# Altium schematic sheet 02-TxCoil.SchDoc
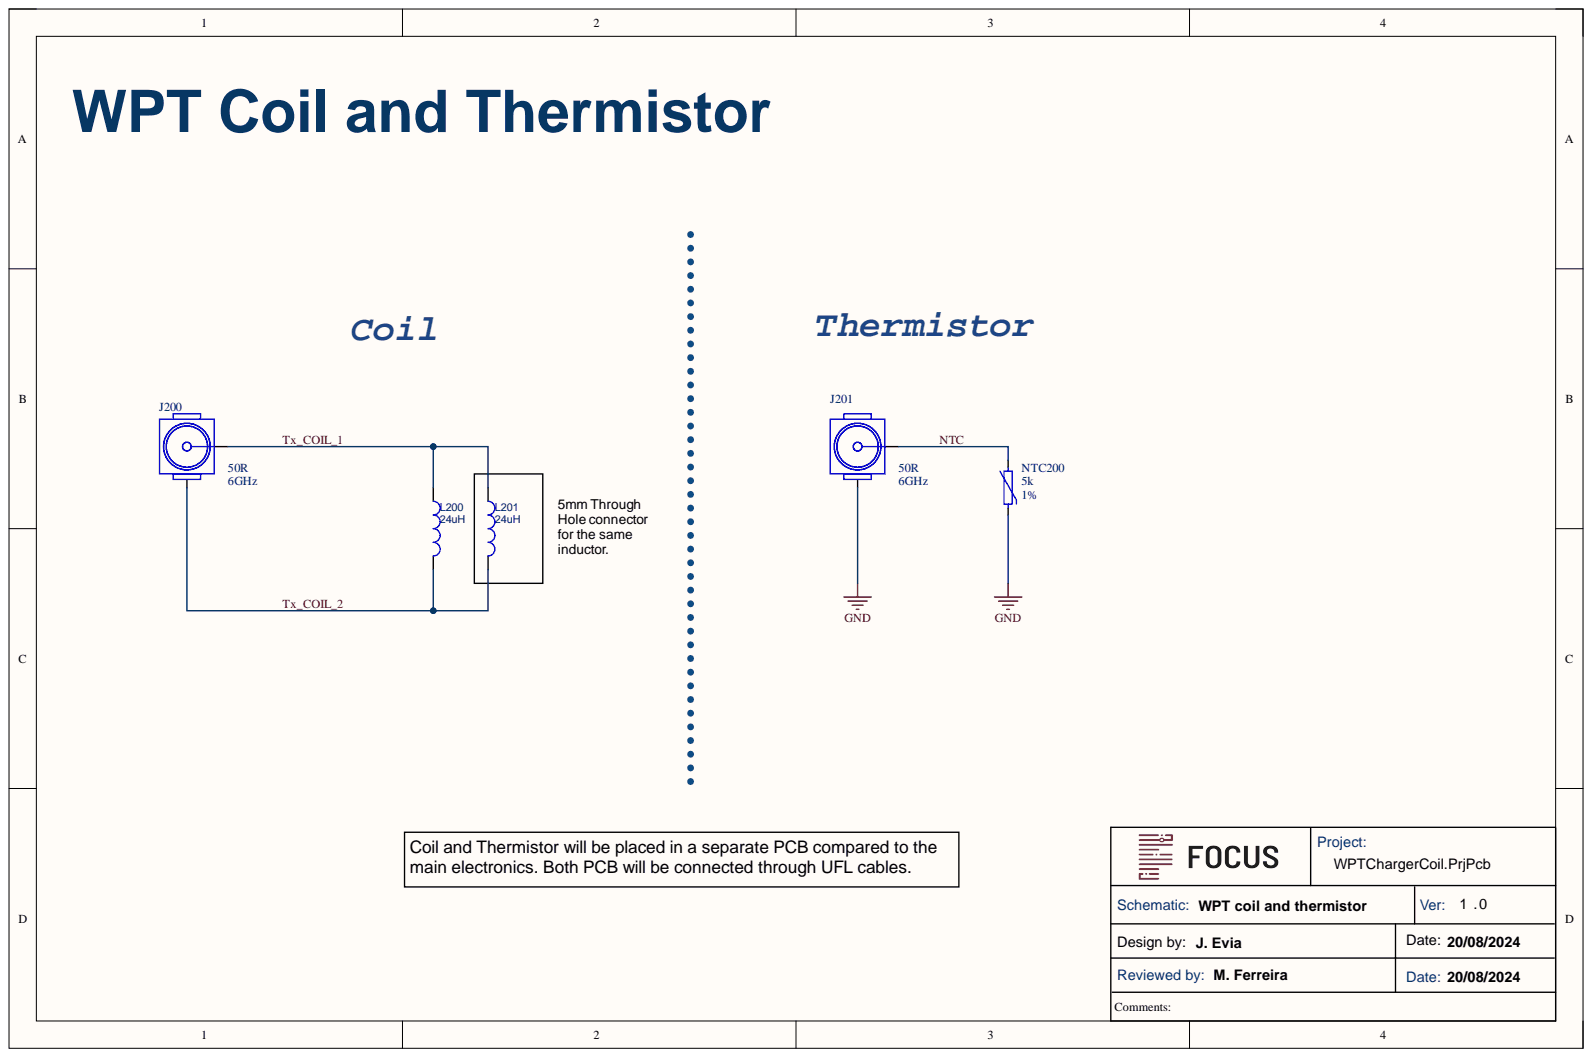
[diagram p0: 02-TxCoil.SchDoc]

## Parts
J200  Characteristic Impedance: 50R  Operating Frequency-Max: 6GHz  [FP-U_FL-R-SMT-1_10-MFG]  (hidden comment: U.FL-R-SMT-1(10))
J201  Characteristic Impedance: 50R  Operating Frequency-Max: 6GHz  [FP-U_FL-R-SMT-1_10-MFG]  (hidden comment: U.FL-R-SMT-1(10))
L200  value 24uH  [760308100110 SMD]  (hidden comment: 760308100110)
L201  value 24uH  [Coil_pads-5mm-ThroughHole]  (hidden comment: 760308100110)
NTC200  value 5k  B Tolerance: 1%  [NTC0603]  (hidden comment: NTCS0603E3502FLT)

## Nets
GND: J201.2 NTC200.1
NTC: J201.1 NTC200.2
Tx_COIL_1: J200.1 L200.2 L201.2
Tx_COIL_2: J200.2 L200.1 L201.1

## Library data of the parts
J200 J201 = U.FL-R-SMT-1(10): RF Connector, 1 Contact(s), Male, Board Mount, Surface Mount Terminal, Receptacle; Manufacturer: Hirose; Body Depth: 0.098inch; Body Diameter: 0.079inch; Contact Resistance: 20mR; Contact Style: Coax; Coupling Type: Snap; Dielectric Withstanding Voltage (AC): 200V; DIN Conformance: No; Durability: 30Cycles; Insertion Loss: 0.05dB; Insulation Resistance: 500MR; Mating Information: MULTIPLE MATING PARTS AVAILABLE; Mounting Option 1: LOCKING; Number of Contacts: 1; Option: General Purpose; Panel Mount: No; Reference Standard: UL; Reliability: COMMERCIAL; VSWR: 1.5; Body/Shell Style: RECEPTACLE; Category: RF / Coaxial Connectors; Component Kind: Standard; ComponentLink1Description: https://datasheet.ciiva.com:443/pdfs/VipMasterIC/IC/HIRO/HIROS10696/HIROS08829-1.pdf?src-supplier=IHS+Markit; ComponentLink1URL: https://datasheet.ciiva.com:443/pdfs/VipMasterIC/IC/HIRO/HIROS10696/HIROS08829-1.pdf?src-supplier=IHS+Markit; ComponentLink2Description: https://datasheet.ciiva.com/11762/0900766b8105243f-11762474.pdf?src-supplier=Rs; ComponentLink2URL: https://datasheet.ciiva.com/11762/0900766b8105243f-11762474.pdf?src-supplier=Rs; ComponentLink3Description: https://datasheet.ciiva.com/7651/91524-7651914.pdf?src-supplier=Element14; ComponentLink3URL: https://datasheet.ciiva.com/7651/91524-7651914.pdf?src-supplier=Element14; ComponentLink4Description: https://datasheet.ciiva.com/7651/91524-7651915.pdf?src-supplier=Element14; ComponentLink4URL: https://datasheet.ciiva.com/7651/91524-7651915.pdf?src-supplier=Element14; Connector Type: RF CONNECTOR; Contact Finish - Mating: GOLD; Contact Finish - Termination: GOLD; Contact Gender: Male; Contact Material: Bronze; Filter Feature: No; IEC Conformance: No; Insulation Color: Beige; Insulation Material: Polymer; JESD-609 Code: e4; Library Name: MP-LIBRARY.IntLib; Manufacturer Part Number: U.FL-R-SMT-1(10); Max Operating Temperature: 90°C; MIL Conformance: No; Min Operating Temperature: -40°C; Mounting Style: STRAIGHT; Mounting Technology: Board; Number of Ports: 1; Pricing: 1=1,35, 10=1,138, 25=1,092, 50=1,0686, 100=1,0221, 250=0,9292, 500=0,83628, 1000=0,74336 (USD); Reach SVHC Compliant: Yes; RoHS: Yes; RoHS Compliant: Yes; Shell Finish: Silver; Shell Material: CuSn; Stock: 112692; Supplier: Digi-Key; Supplier 1: Digikey; Supplier Part Number: H11891CT-ND; Supplier Part Number 1: H11891CT-ND; System: Connector; Termination: SMD/SMT
L200 L201 = 760308100110: 1 Coil, 2 Layer 24µH Wireless Charging Coil Transmitter 70mOhm; Manufacturer: Wurth; Rating: 6A; Category: Coil; Component Kind: Standard; Diameter: 50mm; Library Name: MP-LIBRARY.IntLib; Manufacturer Part Number: 760308100110; Supplier 1: Digikey; Supplier Part Number 1: 732-5283-ND; Thickness: 6mm
NTC200 = NTCS0603E3502FLT: NTC SMD 0603, 5KOHM, 1%, B25/85; Manufacturer: Vishay Dale; Max Power: 100mW; Component Kind: Standard; Library Name: MP-LIBRARY.IntLib; Manufacturer Part Number: NTCS0603E3502FLT; Mounting Type: Surface Mount; Operating Temperature: 40°C ~ 125°C; Package: 0603; Tolerance: 1%
